# Altium schematic sheet main.SchDoc
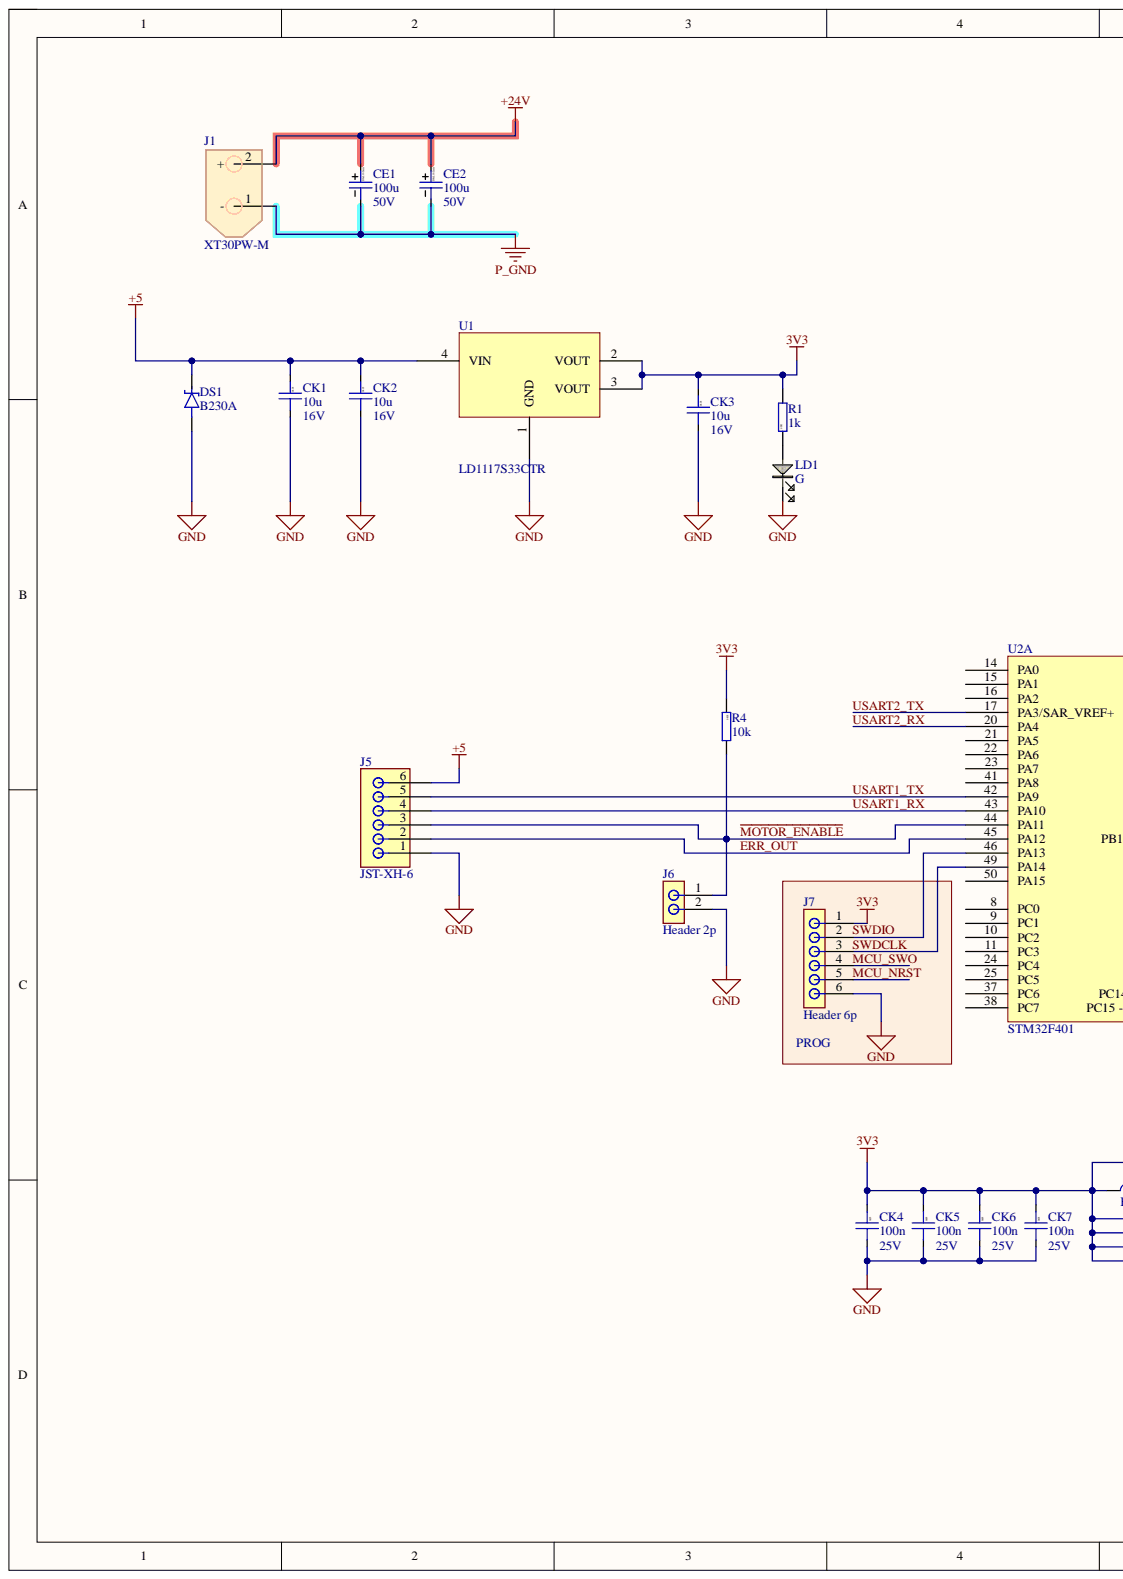
[diagram p0: main.SchDoc, left]
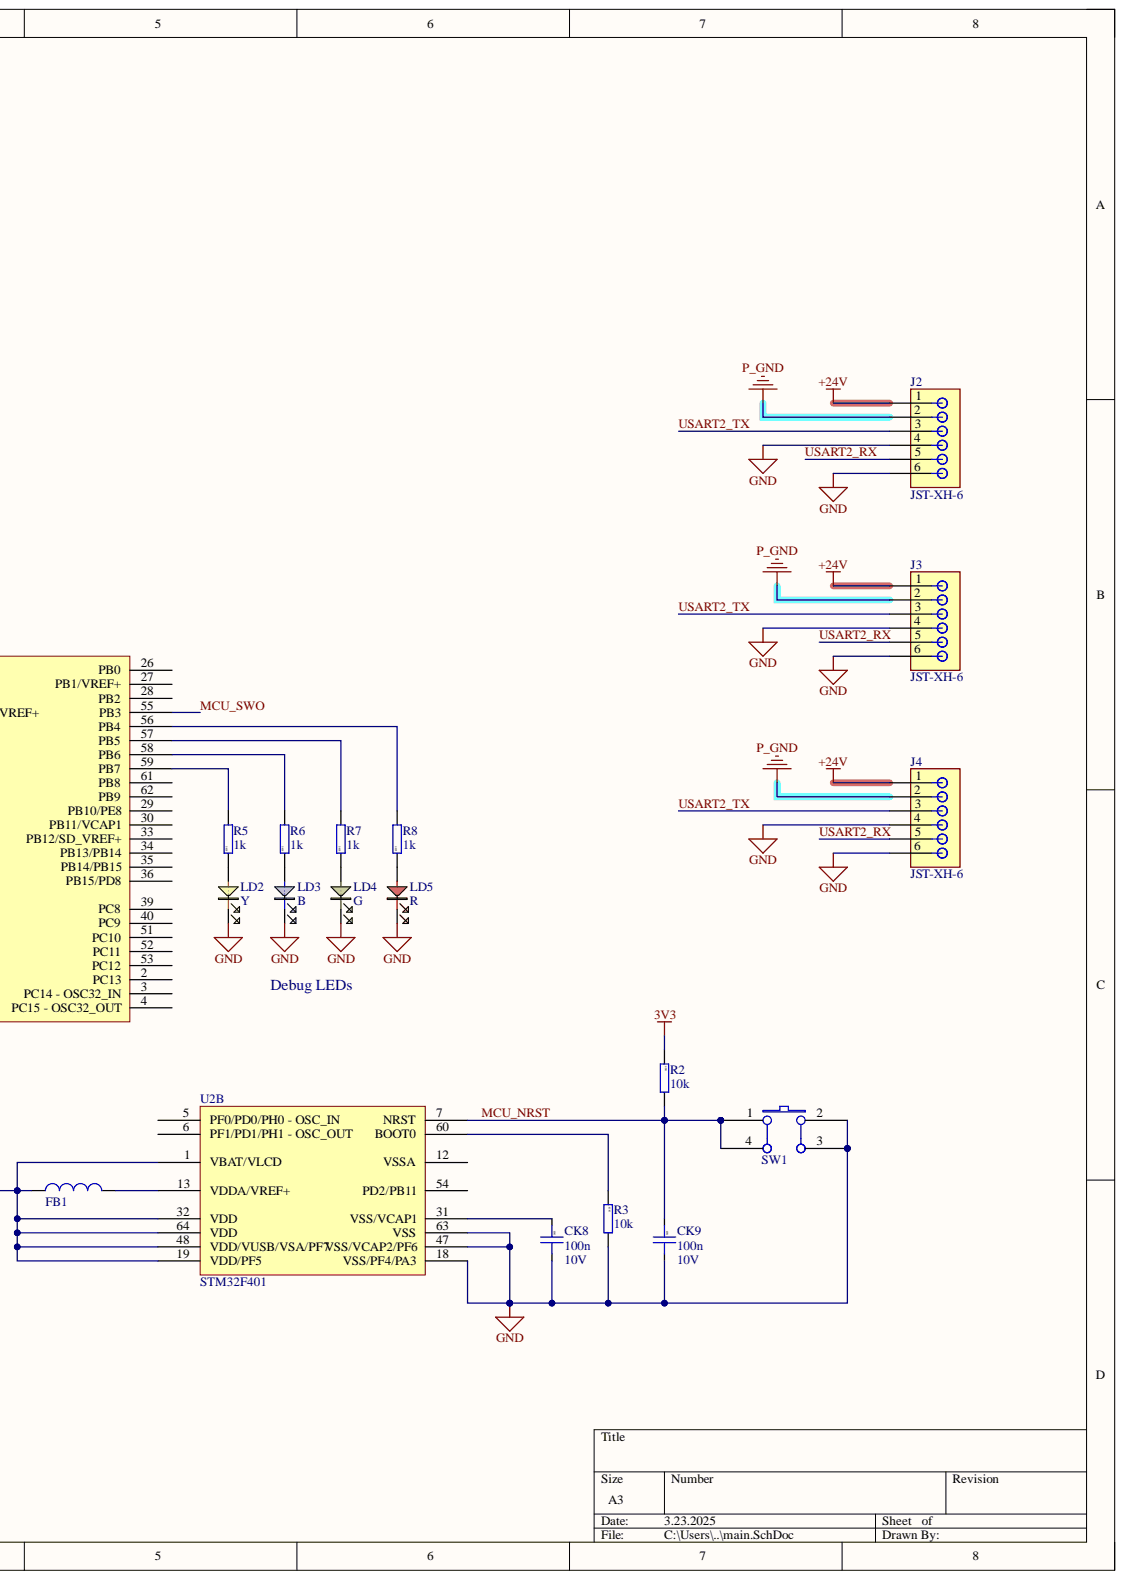
[diagram p1: main.SchDoc, right]

## Parts
CE1  100u  Voltage rating: 50V  [CAPAE830X1100N]
CE2  100u  Voltage rating: 50V  [CAPAE830X1100N]
CK1  10u  Voltage rating: 16V  [CAP0805]
CK2  10u  Voltage rating: 16V  [CAP0805]
CK3  10u  Voltage rating: 16V  [CAP0805]
CK4  100n  Voltage rating: 25V  [CAP0603]
CK5  100n  Voltage rating: 25V  [CAP0603]
CK6  100n  Voltage rating: 25V  [CAP0603]
CK7  100n  Voltage rating: 25V  [CAP0603]
CK8  100n  Voltage rating: 10V  [CAP0603]
CK9  100n  Voltage rating: 10V  [CAP0603]
DS1  B230A  [DIOM4326X23M]
FB1  (no value)  [0603L]
J1  XT30PW-M  [XT30PWM]
J2  JST-XH-6  [JST-XH-6]
J3  JST-XH-6  [JST-XH-6]
J4  JST-XH-6  [JST-XH-6]
J5  JST-XH-6  [JST-XH-6]
J6  Header 2p  [Pin header 2p]
J7  Header 6p  [Pin header 6p]
LD1  G  [0603_LED_G]
LD2  Y  [0603_LED_Y]
LD3  B  [0603_LED_B]
LD4  G  [0603_LED_G]
LD5  R  [0603_LED_R]
R1  1k  Package: 0805  [RESC2012X06N(SMR0805)]
R2  10k  Package: 0805  [RESC2012X06N(SMR0805)]
R3  10k  Package: 0805  [RESC2012X06N(SMR0805)]
R4  10k  Package: 0805  [RESC2012X06N(SMR0805)]
R5  1k  Package: 0805  [RESC2012X06N(SMR0805)]
R6  1k  Package: 0805  [RESC2012X06N(SMR0805)]
R7  1k  Package: 0805  [RESC2012X06N(SMR0805)]
R8  1k  Package: 0805  [RESC2012X06N(SMR0805)]
SW1  SW PushButton 6mm  [Pushbutton smd 6mm]  (value not drawn)
U1  LD1117S33CTR  [SOT230P700X180-4M]
U2  STM32F401  [LQFP64]  (parts A,B)

## Nets
3V3: CK3.1 CK4.1 CK5.1 CK6.1 CK7.1 FB1.1 J7.1 R1.1 R2.2 R4.2 U1.2(VOUT) U1.3(VOUT) U2.1(VBAT/VLCD) U2.19(VDD/PF5) U2.32(VDD) U2.48(VDD/VUSB/VSA/PF7) U2.64(VDD)
+5: CK1.1 CK2.1 DS1.1 J5.6 U1.4(VIN)
+24V: CE1.1 CE2.1 J1.2 J2.1 J3.1 J4.1
GND: CK1.2 CK2.2 CK3.2 CK4.2 CK5.2 CK6.2 CK7.2 CK8.2 CK9.2 DS1.2 J2.4 J2.6 J3.4 J3.6 J4.4 J4.6 J5.1 J6.2 J7.6 LD1.2 LD2.2 LD3.2 LD4.2 LD5.2 R3.1 SW1.2 SW1.3 U1.1(GND) U2.18(VSS/PF4/PA3) U2.47(VSS/VCAP2/PF6) U2.63(VSS)
P_GND: CE1.2 CE2.2 J1.1 J2.2 J3.2 J4.2
~{MOTOR_ENABLE}: J5.3 J6.1 R4.1 U2.44(PA11)
ERR_OUT: J5.2 U2.45(PA12)
MCU_NRST: CK9.1 J7.5 R2.1 SW1.1 SW1.4 U2.7(NRST)
MCU_SWO: J7.4 U2.55(PB3)
SWDCLK: J7.3 U2.49(PA14)
SWDIO: J7.2 U2.46(PA13)
USART1_RX: J5.4 U2.43(PA10)
USART1_TX: J5.5 U2.42(PA9)
USART2_RX: J2.5 J3.5 J4.5 U2.20(PA4)
USART2_TX: J2.3 J3.3 J4.3 U2.17(PA3/SAR_VREF+)
NetCK8_1: CK8.1 U2.31(VSS/VCAP1)
NetFB1_2: FB1.2 U2.13(VDDA/VREF+)
NetLD1_1: LD1.1 R1.2
NetLD2_1: LD2.1 R5.2
NetLD3_1: LD3.1 R6.2
NetLD4_1: LD4.1 R7.2
NetLD5_1: LD5.1 R8.2
NetR3_2: R3.2 U2.60(BOOT0)
NetR5_1: R5.1 U2.59(PB7)
NetR6_1: R6.1 U2.58(PB6)
NetR7_1: R7.1 U2.57(PB5)
NetR8_1: R8.1 U2.56(PB4)

Not connected: U2 2(PC13) 3(PC14_-_OSC32_IN) 4 5 6 8(PC0) 9(PC1) 10(PC2) 11(PC3) 12(VSSA) 14(PA0) 15(PA1) 16(PA2) 21(PA5) 22(PA6) 23(PA7) 24(PC4) 25(PC5) 26(PB0) 27(PB1/VREF+) 28(PB2) 29(PB10/PE8) 30(PB11/VCAP1) 33(PB12/SD_VREF+) 34(PB13/PB14) 35(PB14/PB15) 36(PB15/PD8) 37(PC6) 38(PC7) 39(PC8) 40(PC9) 41(PA8) 50(PA15) 51(PC10) 52(PC11) 53(PC12) 54(PD2/PB11) 61(PB8) 62(PB9)

## Library data of the parts
CE1 CE2 = Cap el 100u 50V: 100uF 50V ±20% SMD,D8xL10.2mm Aluminum Electrolytic Capacitors - SMD ROHS; Component Kind: Standard; JLCPCB Part: C3352; Value: 100u; Library Name: Kubikova ultra knihovna.SchLib
CK1-CK3 = Cap 10u 0805: 16V 10uF X5R ±10% 0805 Multilayer Ceramic Capacitors MLCC - SMD/SMT ROHS; Component Kind: Standard; JLCPCB Part: C1713; Value: 10u; Library Name: Kubikova ultra knihovna.SchLib
CK4-CK7 = Cap 100n 0603: 25V 100nF X7R ±10% 0603 Multilayer Ceramic Capacitors MLCC - SMD/SMT ROHS; Component Kind: Standard; JLCPCB Part: C1590; Value: 100n; Library Name: Kubikova ultra knihovna.SchLib
CK8 CK9 = Cap 2u2 0603: 10V 2.2uF X5R ±10% 0603 Multilayer Ceramic Capacitors MLCC - SMD/SMT ROHS; Component Kind: Standard; JLCPCB Part: C1607; Value: 100n; Library Name: Kubikova ultra knihovna.SchLib
DS1 = B230A-13-F: 30V 500mV@2A 2A SMA(DO-214AC) Schottky Diodes ROHS; Component Kind: Standard; JLCPCB Part: C12889; Library Name: Kubikova ultra knihovna.SchLib
FB1 = Ferrite bead 0603: 450mΩ ±25% 600Ω@100MHz 0603 Ferrite Beads ROHS; Component Kind: Standard; JLCPCB Part: C1002; Published: 8-Jun-2000; Revision: July-2002: Re-released for DXP Platform.; Library Name: Kubikova ultra knihovna.SchLib; Publisher: Altium Limited
J1 = XT30 male: plug; Current: 15A; Component Kind: Standard; ComponentLink1Description: New link; ComponentLink1URL: https://www.tme.eu/cz/details/xt30pw-m/konektory-dc/amass/; JLCPCB Part: C431092; Library Name: Kubikova ultra knihovna.SchLib
J2-J5 = JST-HX-6: 1x6P 6P XH Tin 6 -25℃~+85℃ 3A 1 2.5mm Brass Bend insert Push-Pull,P=2.5mm Wire To Board Connector ROHS; S6B-XH-A(LF)(SN); Component Kind: Standard; JLCPCB Part: C157919; Library Name: Kubikova ultra knihovna.SchLib
J6 = Pin header 2p: Connector; Manufacturer_Name: Connfly; Manufacturer_Part_Number: DS1021-1*2SF11-B; Component Kind: Standard; Datasheet Link: https://www.tme.com/Document/cc301e58a5da78cb543a94b5a5b7f06a/DS1021.pdf; Height: 5.88mm; JLCPCB Part: C492401; Library Name: Kubikova ultra knihovna.SchLib
J7 = Pin header 6p: 3A Direct Insert Policy 6P -40℃~+105℃ 2.54mm Single Row Black Brass 1x6P Plugin,P=2.54mm Pin Headers ROHS; Manufacturer_Name: Connfly; Manufacturer_Part_Number: DS1021-1*2SF11-B; Component Kind: Standard; Datasheet Link: https://www.tme.com/Document/cc301e58a5da78cb543a94b5a5b7f06a/DS1021.pdf; Height: 5.88mm; JLCPCB Part: C37208; Library Name: Kubikova ultra knihovna.SchLib
LD1 LD4 = LED G 0603: 0603 Green Led; Component Kind: Standard; JLCPCB Part: C22371297; Library Name: Kubikova ultra knihovna.SchLib
LD2 = LED Y 0603: 0603 Yellow Led; Component Kind: Standard; JLCPCB Part: C7496811; Library Name: Kubikova ultra knihovna.SchLib
LD3 = LED B 0603: 0603 Blue Led; Component Kind: Standard; JLCPCB Part: C7496819; Library Name: Kubikova ultra knihovna.SchLib
LD5 = LED R 0603: 0603 Red Led; Component Kind: Standard; JLCPCB Part: C7496820; Library Name: Kubikova ultra knihovna.SchLib
R1 R5-R8 = Res 1k 0805: 125mW Thick Film Resistors 150V ±100ppm/℃ ±1% 1kΩ 0805 Chip Resistor - Surface Mount ROHS; Tolerance & Rating: 1%/0.1W; Component Kind: Standard; ComponentLink3Description: rezistor čip; ComponentLink3URL: heliosgreen://v1/hegMT/5-MT/Classes(343)/Folders/OpenForm?RecordNumbers=[381513]; JLCPCB Part: C17513; Simulation: Simple; SMD: 1; Value: 1k; Author: JP/27.03.2014; Library Name: Kubikova ultra knihovna.SchLib
R2-R4 = Res 10k 0805: 125mW Thick Film Resistors 150V ±100ppm/℃ ±1% 10kΩ 0805 Chip Resistor - Surface Mount ROHS; Tolerance & Rating: 1%/0.1W; Component Kind: Standard; ComponentLink3Description: rezistor čip; ComponentLink3URL: heliosgreen://v1/hegMT/5-MT/Classes(343)/Folders/OpenForm?RecordNumbers=[381513]; JLCPCB Part: C17414; Simulation: Simple; SMD: 1; Value: 10k; Library Name: Kubikova ultra knihovna.SchLib
SW1 = SW PushButton 6mm: 6mm 4.3mm Round Button 50mA Standing paste 6mm SPST 100MΩ 100000 Times 250gf 12V SMD Tactile Switches ROHS; Component Kind: Standard; JLCPCB Part: C83916; Library Name: Kubikova ultra knihovna.SchLib
U1 = LD1117S33CTR: Component Kind: Standard; JLCPCB Part: C86781; Library Name: Kubikova ultra knihovna.SchLib
U2 = STM32F401: Component Kind: Standard; JLCPCB Part: C74524; Library Name: Kubikova ultra knihovna.SchLib
